# Altium schematic sheet LS_R1.1.SchDoc
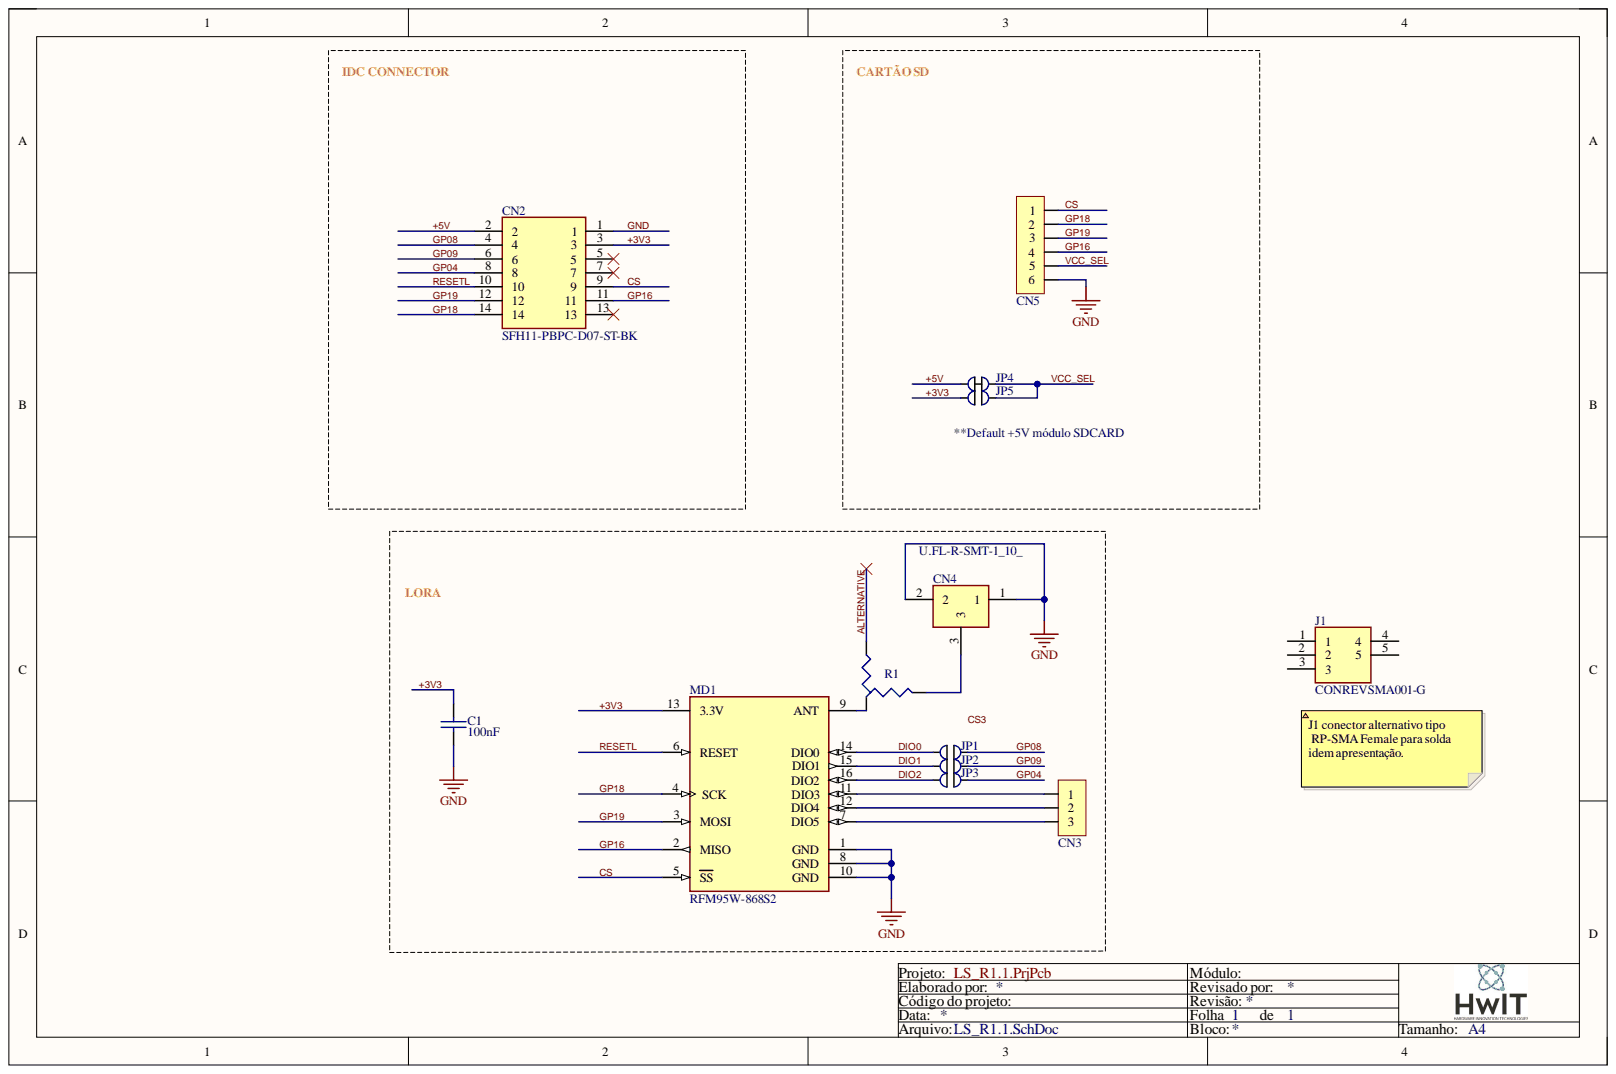
[diagram p0: LS_R1.1.SchDoc]

## Parts
C1  100nF  [C0603]
CN2  SFH11-PBPC-D07-ST-BK  [SULLINS_SFH11-PBPC-D07-ST-BK]
CN3  HD 1X3 HOR  [HEADER3 VERT]  (value not drawn)
CN4  U.FL-R-SMT-1_10_  [U.FL-R-SMT-1(10)]
CN5  HEADER 1x6 V 2.54MM  [HEADER 6 VERT 2.54mm]  (value not drawn)
J1  CONREVSMA001-G  [CONREVSMA001G]  (value not drawn)
JP1  JUMPER CLOSER  [Jumper_OPEN]  (value not drawn)
JP2  JUMPER CLOSER  [Jumper_OPEN]  (value not drawn)
JP3  JUMPER CLOSER  [Jumper_OPEN]  (value not drawn)
JP4  JUMPER CLOSER  [Jumper_Closer]  (value not drawn)
JP5  JUMPER CLOSER  [Jumper_OPEN]  (value not drawn)
MD1  RFM95W-868S2  [FP-RFM95W-868S2-MFG]
R1  JUMPER_0402_v  [R0402 - JUMPER - v]  (value not drawn)

## Nets
+3V3: C1.1 CN2.3 JP5.1 MD1.13(3.3V)
+5V: CN2.2 JP4.2
ALTERNATIVE: R1.1
CS: CN2.9 CN5.1 MD1.5(SS)
DIO0: JP1.1 MD1.14(DIO0)
DIO1: JP2.1 MD1.15(DIO1)
DIO2: JP3.1 MD1.16(DIO2)
GND: C1.2 CN2.1 CN4.1 CN4.2 CN5.6 MD1.1(GND) MD1.8(GND) MD1.10(GND)
GP04: CN2.8 JP3.2
GP08: CN2.4 JP1.2
GP09: CN2.6 JP2.2
GP16: CN2.11 CN5.4 MD1.2(MISO)
GP18: CN2.14 CN5.2 MD1.4(SCK)
GP19: CN2.12 CN5.3 MD1.3(MOSI)
RESETL: CN2.10 MD1.6(RESET)
VCC_SEL: CN5.5 JP4.1 JP5.2
NetCN3_1: CN3.1 MD1.11(DIO3)
NetCN3_2: CN3.2 MD1.12(DIO4)
NetCN3_3: CN3.3 MD1.7(DIO5)
NetCN4_3: CN4.3 R1.3
NetMD1_9: MD1.9(ANT) R1.2
CS3:

Not connected: CN2 5 7 13; J1 1 2 3 4 5

## Library data of the parts
C1 = __uF 0603: CAP CER 0.1UF 50V X7R 0603; Manufacturer: YAGEO; Manufacturer PN: CC0603ZRY5V9BB104; Component Kind: Standard; Digi-Key Part Number: 311-1343-6-ND; Library Name: Capacitores.SchLib; Mouser Part Number: 603-C0603ZRY5V9BB104
CN2 = SFH11-PBPC-D07-ST-BK: 14 Position Header Connector Through Hole; Manufacturer: Sullins Connector; Availability: Warning; Check_prices: https://www.snapeda.com/parts/SFH11-PBPC-D07-ST-BK/Sullins%20Connector%20Solutions/view-part/851415/?ref=eda; Component Kind: Standard; Library Name: Conectores.SchLib; Manufacturer PN: SFH11-PBPC-D07-ST-BK; Package: None; Price: None; Purchase-URL: https://pricing.snapeda.com/search/part/SFH11-PBPC-D07-ST-BK/?ref=eda; SnapEDA_Link: https://www.snapeda.com/parts/SFH11-PBPC-D07-ST-BK/Sullins%20Connector%20Solutions/view-part/851415/?ref=snap
CN3 = HEADER 1x3 v 2.54MM: CONN HEADER 3POS VERT 2.54MM; Manufacturer: TE Connectivity; Component Kind: Standard; Library Name: Conectores.SchLib
CN4 = U.FL-R-SMT-1_10_: CONN U.FL RCPT STR 50 OHM SMD; Manufacturer: Hirose; Arrow Part Number: U.FL-R-SMT-1(10); Arrow Price/Stock: https://www.arrow.com/en/products/u.fl-r-smt-1-10/hirose-electric; Component Kind: Standard; Datasheet Link: https://www.hirose.com/product/document?clcode=CL0331-0472-2-10&productname=U.FL-R-SMT-1(10)&series=U.FL&documenttype=2DDrawing&lang=en&documentid=0000940684; Manufacturer PN: U.FL-R-SMT-1(10); Mouser Part Number: 798-U.FL-R-SMT-110; Mouser Price/Stock: https://www.mouser.co.uk/ProductDetail/Hirose-Connector/U.FL-R-SMT-110?qs=kL1ClTr6oBoOdtqlGQpQrQ%3D%3D
CN5 = HEADER 1x6 V 2.54MM: CONN HEADER 6POS VERT 2.5MM; Component Kind: Standard; Library Name: Conectores.SchLib
J1 = CONREVSMA001-G: Connector; Manufacturer_Name: Linx Technologies; Component Kind: Standard; Datasheet Link: https://linxtechnologies.com/wp/wp-content/uploads/conrevsma001-g.pdf; Height: 9mm; Library Name: SamacSys.SchLib; Manufacturer_Part_Number: CONREVSMA001-G; Mouser Part Number: 712-CONREVSMA001-G; Mouser Price/Stock: https://www.mouser.co.uk/ProductDetail/Linx-Technologies/CONREVSMA001-G?qs=W0r2Z67sYILo7qw9wjKWjA%3D%3D
JP1-JP3 JP5 = JSO: Component Kind: Standard; Description: JUMPER CLOSER; Library Name: SamacSys.SchLib
JP4 = JSC: Component Kind: Standard; Description: JUMPER CLOSER; Library Name: SamacSys.SchLib
MD1 = CMP-204316-000001-1: RX TXRX MODULE ISM < 1GHZ SMD; Manufacturer: RF Solutions; Current - Receiving: 12.1mA; Current - Transmitting: 120mA; Data Rate: 300Kbps; Frequency: 868MHz; Interface: SPI; Sensitivity: -123dBm; Case/Package: SMD/SMT; Component Kind: Standard; Library Name: Altium Content Vault; Manufacturer PN: RFM95W-868S2; Max Operating Temperature: 70°C; Min Operating Temperature: -20°C; Mount: Surface Mount; Packaging: Bulk
R1 = JUMPER_0402_v: RES SMD 0 OHM JUMPER 1/10W 0402; Component Kind: Standard; Library Name: Resistores.SchLib
